# Altium schematic sheet 热网系统.SchDoc(热网系统)
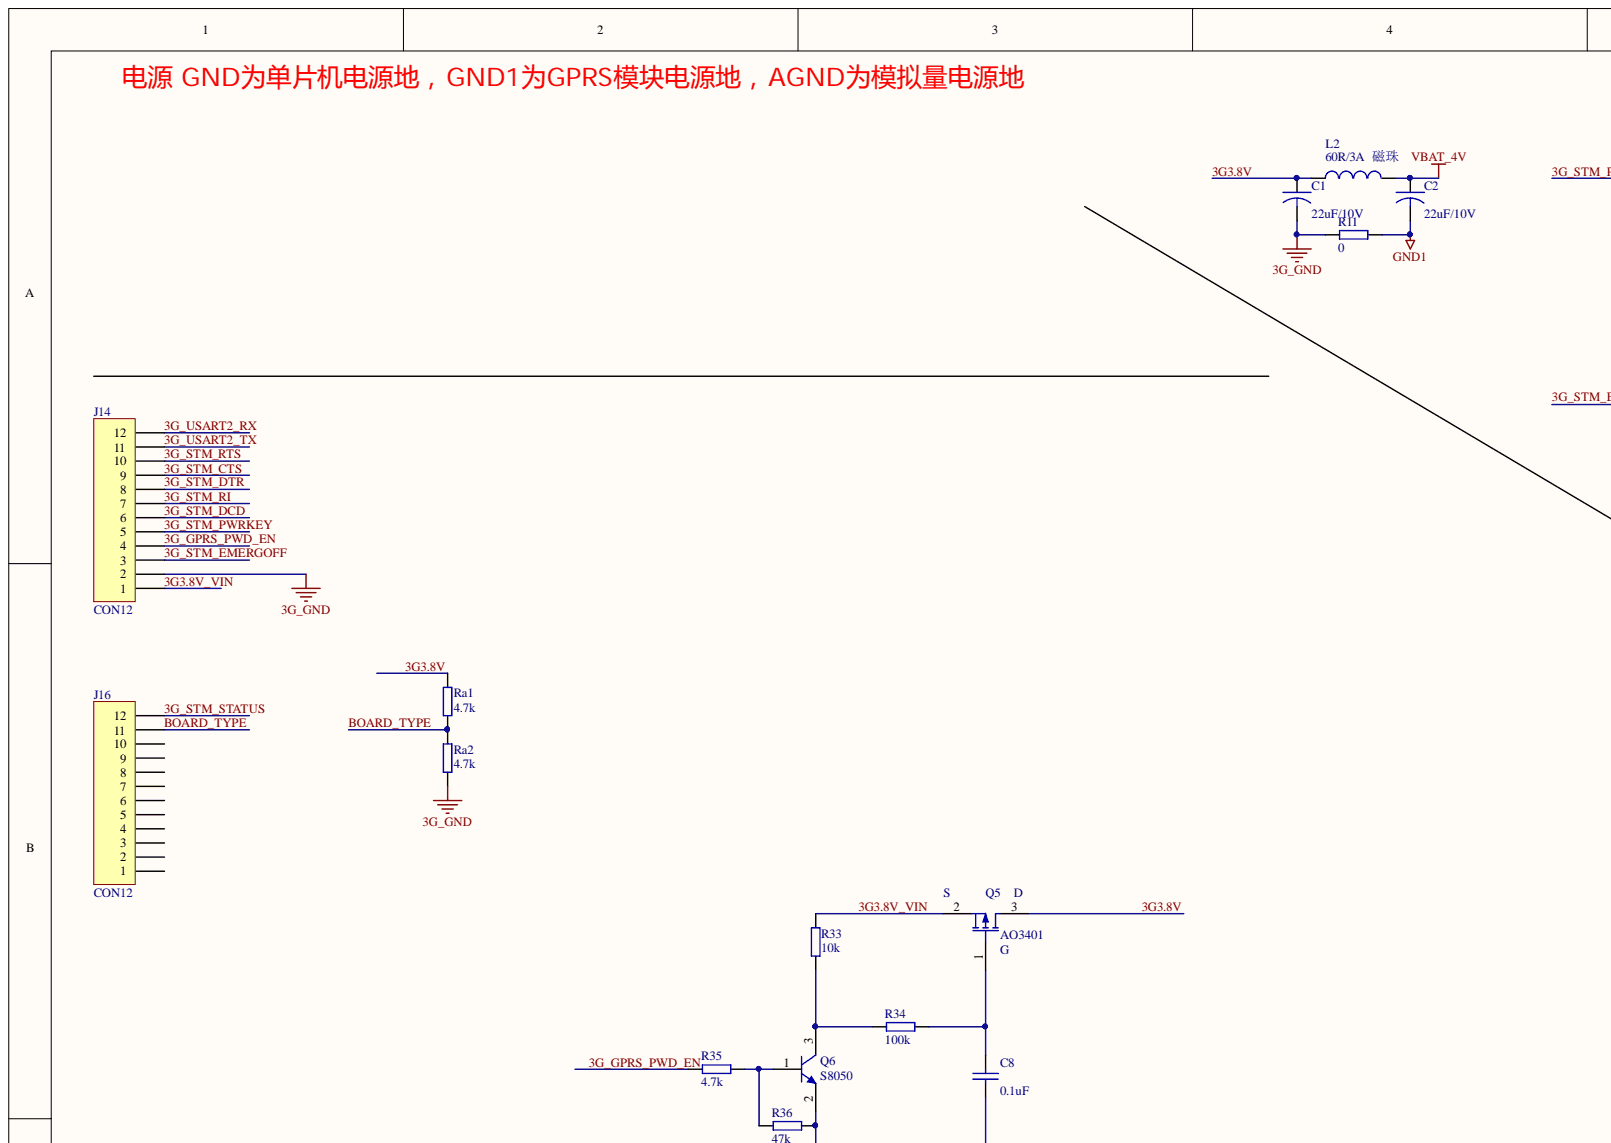
[diagram p0: 热网系统.SchDoc, top left]
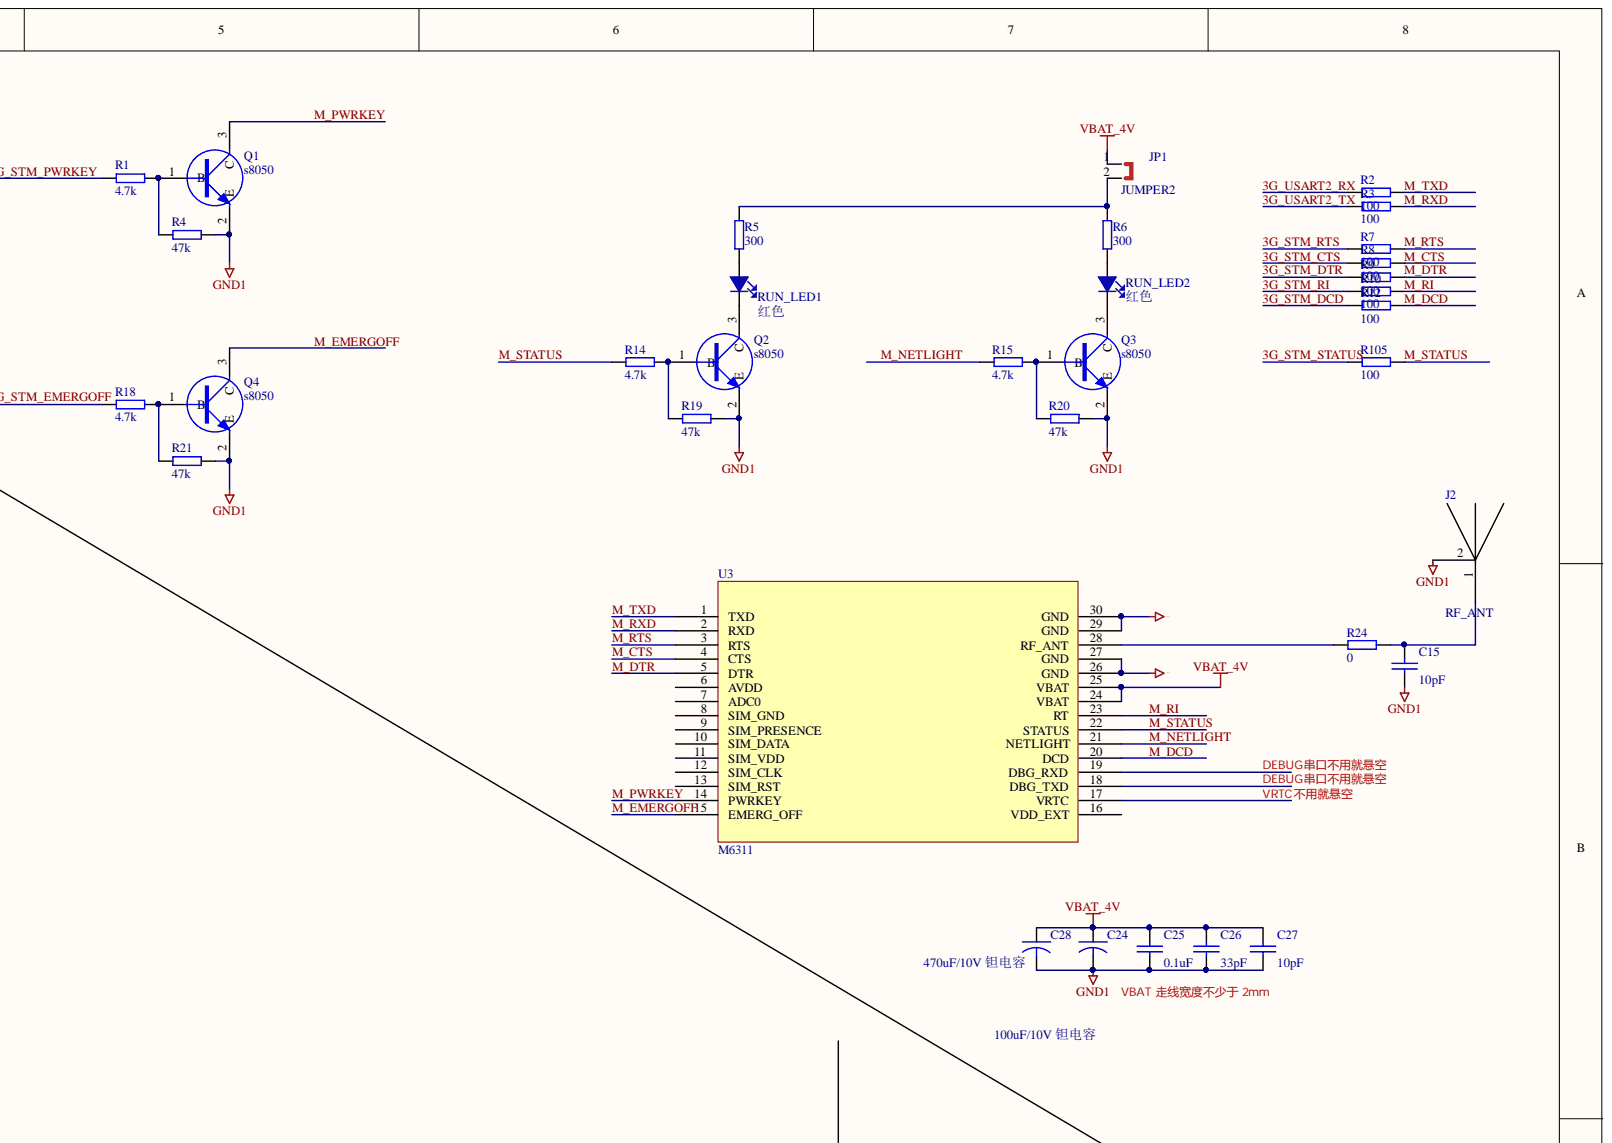
[diagram p1: 热网系统.SchDoc, top right]
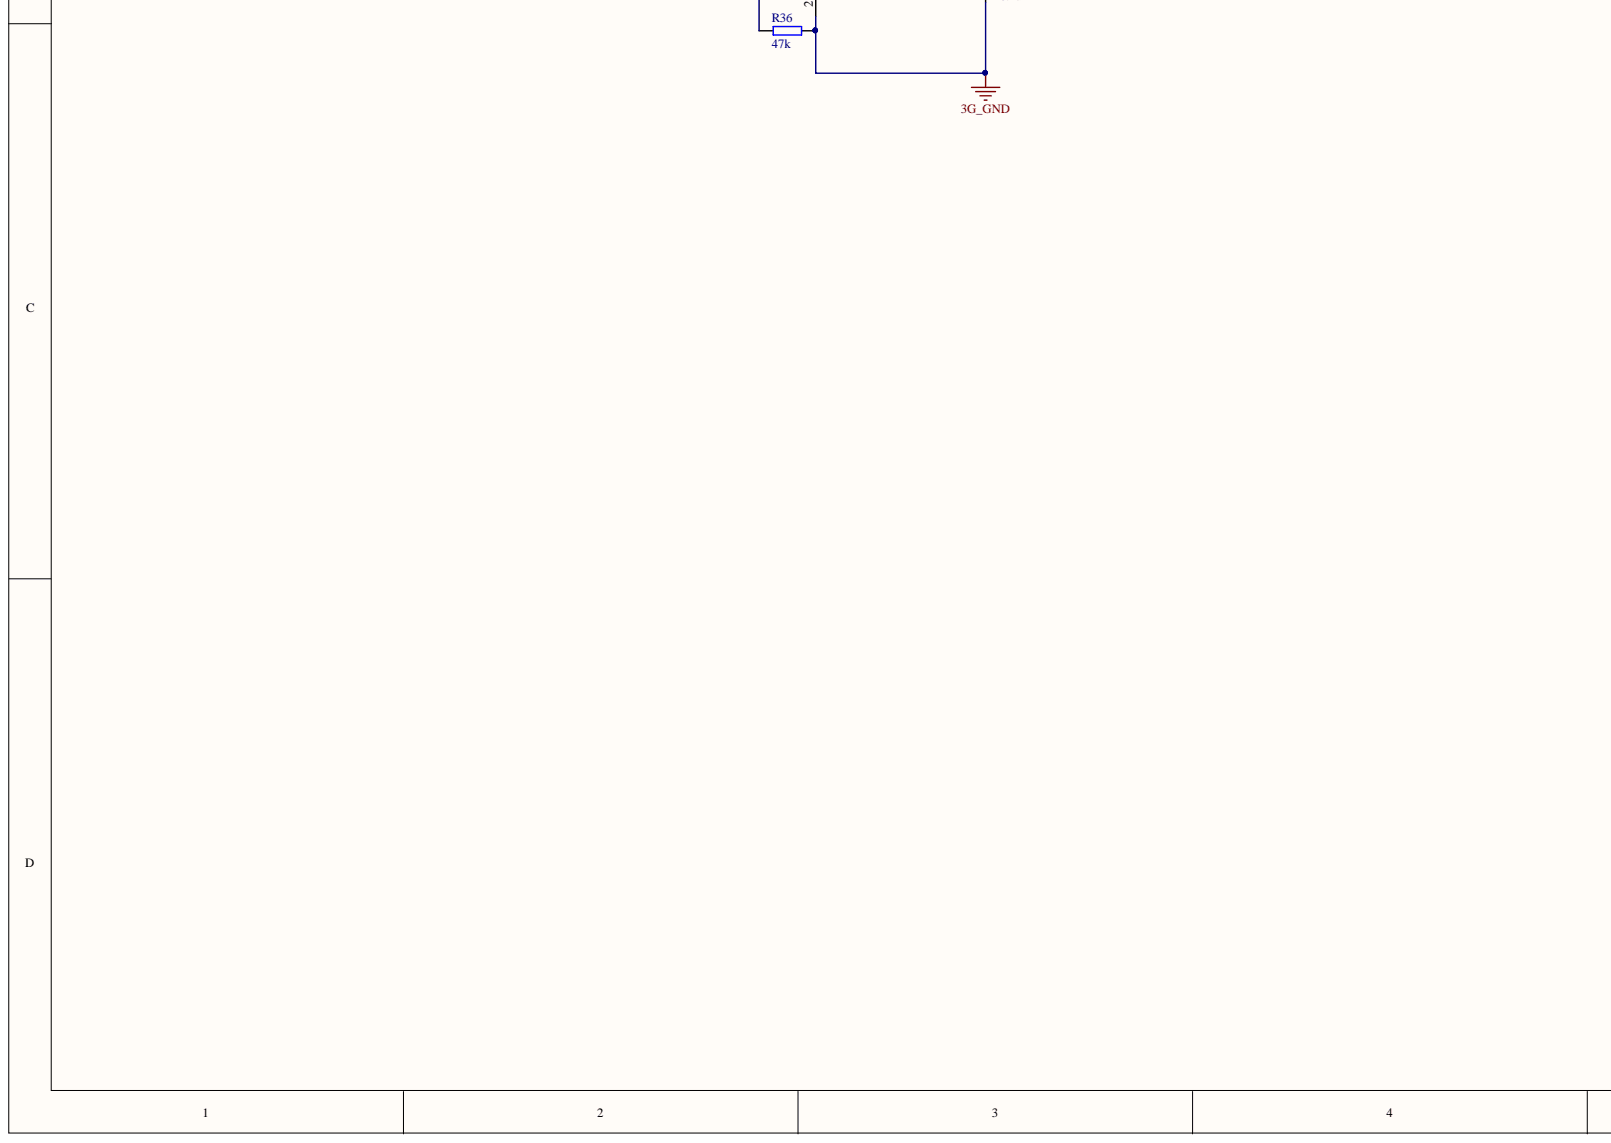
[diagram p2: 热网系统.SchDoc, bottom left]
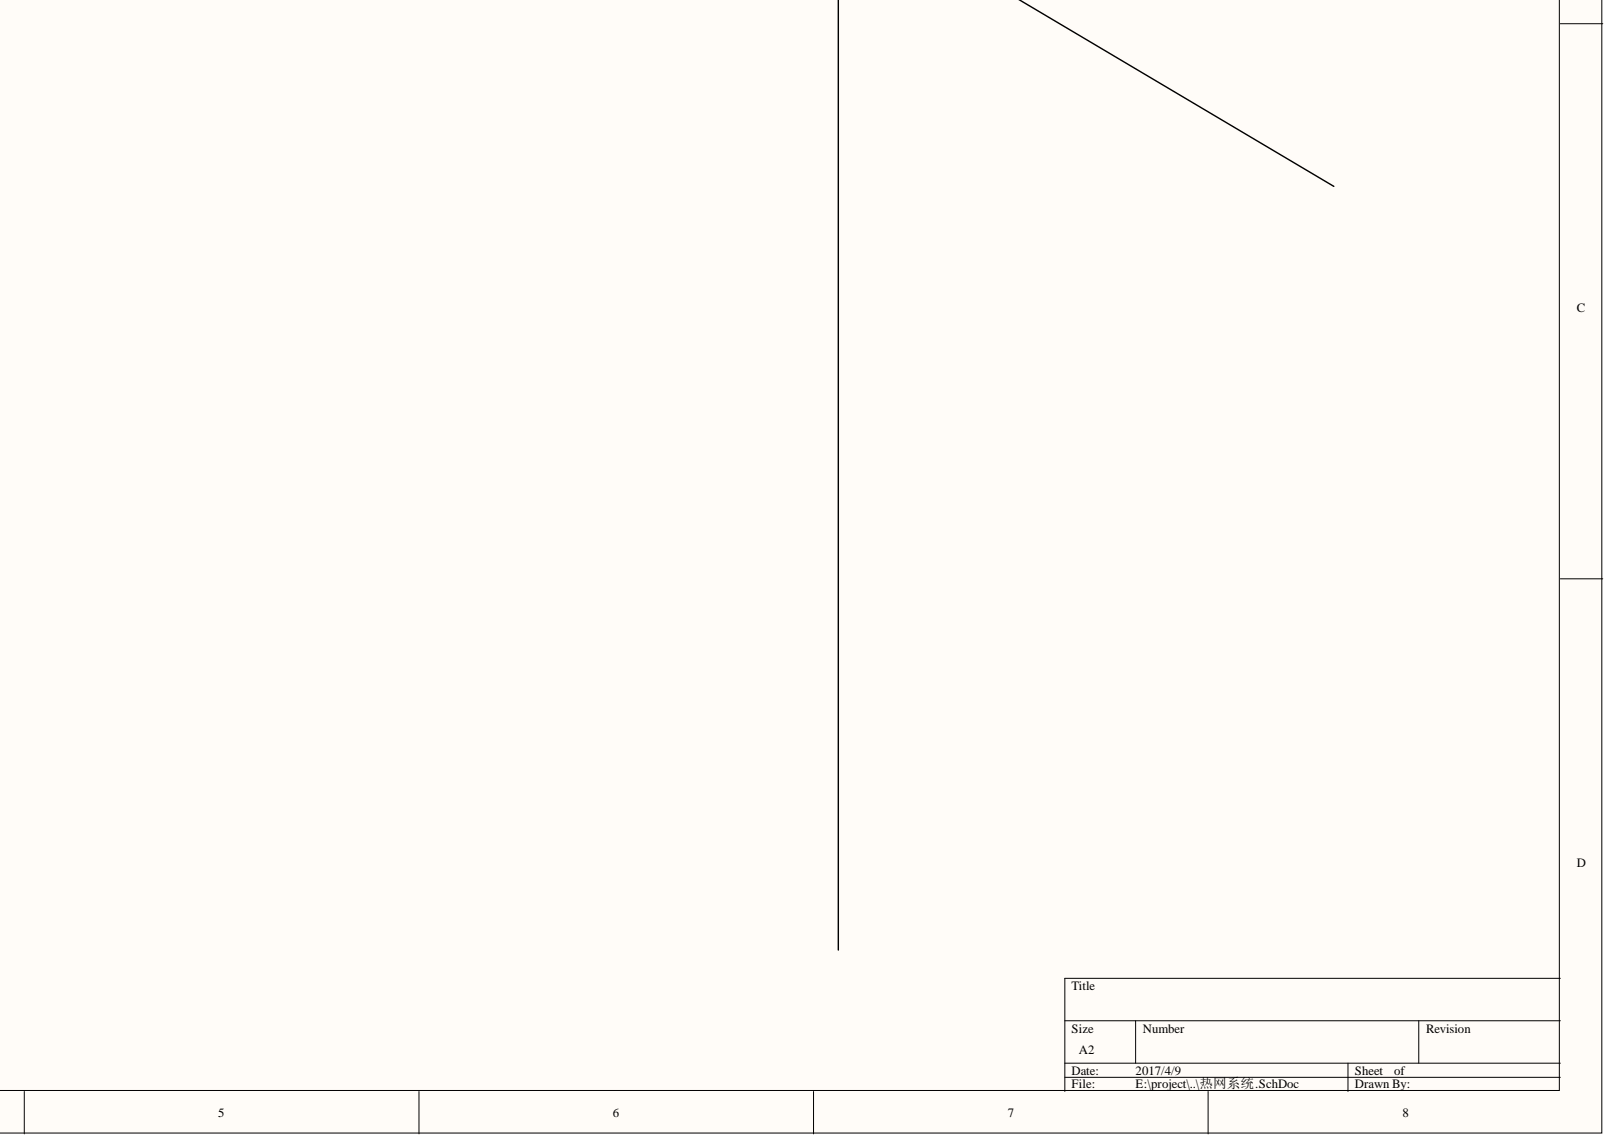
[diagram p3: 热网系统.SchDoc, bottom right]

## Parts
C1  22uF/10V  [CAP-1206]
C2  22uF/10V  [CAP-1206]
C8  0.1uF  [0603-1]
C15  10pF  [0603-1]
C24  100uF/10V 钽电容  [CAP-1210]
C25  0.1uF  [0603-1]
C26  33pF  [0603-1]
C27  10pF  [0603-1]
C28  470uF/10V 钽电容  [CAP-7343]
J2  RF_ANT  [U.FL-SMT]
J14  CON12  [HDR2X6 1.27]
J16  CON12  [HDR2X6 1.27]
JP1  JUMPER2  [HDR2X1-2.54]
L2  60R/3A  磁珠  [1206]
Q1  s8050  [SOT-23]
Q2  s8050  [SOT-23]
Q3  s8050  [SOT-23]
Q4  s8050  [SOT-23]
Q5  AO3401  [SOT23-3]
Q6  S8050  [SOT23-3]
R1  4.7k  [0603-1]
R2  100  [0603-1]
R3  100  [0603-1]
R4  47k  [0603-1]
R5  300  [0603-1]
R6  300  [0603-1]
R7  100  [0603-1]
R8  100  [0603-1]
R9  100  [0603-1]
R10  100  [0603-1]
R11  0  [0603-1]
R12  100  [0603-1]
R14  4.7k  [0603-1]
R15  4.7k  [0603-1]
R18  4.7k  [0603-1]
R19  47k  [0603-1]
R20  47k  [0603-1]
R21  47k  [0603-1]
R24  0  [0603-1]
R33  10k  [0603-1]
R34  100k  [0603-1]
R35  4.7k  [0603-1]
R36  47k  [0603-1]
R105  100  [0603-1]
Ra1  4.7k  [0603-1]
Ra2  4.7k  [0603-1]
RUN_LED1  红色  [LED-0805]
RUN_LED2  红色  [LED-0805]
U3  M6311  [M6311]

## Nets
3G_GND: C1.2 C8.2 J14.2 Q6.2 R11.2 R36.1 Ra2.2
GND1: C2.2 C15.2 C24.2 C25.2 C26.2 C27.2 C28.2 J2.2 Q1.2 Q2.2 Q3.2 Q4.2 R4.1 R11.1 R19.1 R20.1 R21.1 U3.26(GND) U3.27(GND) U3.29(GND) U3.30(GND)
VBAT_4V: C2.1 C24.1 C25.1 C26.1 C27.1 C28.1 JP1.1 L2.2 U3.24(VBAT) U3.25(VBAT)
3G3.8V: C1.1 L2.1 Q5.3 Ra1.1
3G3.8V_VIN: J14.1 Q5.2 R33.1
3G_GPRS_PWD_EN: J14.4 R35.1
3G_STM_CTS: J14.9 R8.2
3G_STM_DCD: J14.6 R12.2
3G_STM_DTR: J14.8 R9.2
3G_STM_EMERGOFF: J14.3 R18.2
3G_STM_PWRKEY: J14.5 R1.2
3G_STM_RI: J14.7 R10.2
3G_STM_RTS: J14.10 R7.2
3G_STM_STATUS: J16.12 R105.2
3G_USART2_RX: J14.12 R2.2
3G_USART2_TX: J14.11 R3.2
BOARD_TYPE: J16.11 Ra1.2 Ra2.1
M_CTS: R8.1 U3.4(CTS)
M_DCD: R12.1 U3.20(DCD)
M_DTR: R9.1 U3.5(DTR)
M_EMERGOFF: Q4.3 U3.15(EMERG_OFF)
M_NETLIGHT: R15.2 U3.21(NETLIGHT)
M_PWRKEY: Q1.3 U3.14(PWRKEY)
M_RI: R10.1 U3.23(RT)
M_RTS: R7.1 U3.3(RTS)
M_RXD: R3.1 U3.2(RXD)
M_STATUS: R14.2 R105.1 U3.22(STATUS)
M_TXD: R2.1 U3.1(TXD)
NetC8_1: C8.1 Q5.1 R34.2
NetC15_1: C15.1 J2.1 R24.1
NetJP1_2: JP1.2 R5.1 R6.1
NetQ1_1: Q1.1 R1.1 R4.2
NetQ2_1: Q2.1 R14.1 R19.2
NetQ2_3: Q2.3 RUN_LED1.2
NetQ3_1: Q3.1 R15.1 R20.2
NetQ3_3: Q3.3 RUN_LED2.2
NetQ4_1: Q4.1 R18.1 R21.2
NetQ6_1: Q6.1 R35.2 R36.2
NetQ6_3: Q6.3 R33.2 R34.1
NetR5_2: R5.2 RUN_LED1.1
NetR6_2: R6.2 RUN_LED2.1
NetR24_2: R24.2 U3.28(RF_ANT)

Not connected: J16 1 2 3 4 5 6 7 8 9 10; U3 6(AVDD) 7(ADC0) 8(SIM_GND) 9(SIM_PRESENCE) 10(SIM_DATA) 11(SIM_VDD) 12(SIM_CLK) 13(SIM_RST) 16(VDD_EXT) 17(VRTC) 18(DBG_TXD) 19(DBG_RXD)

## Library data of the parts
C1 C2 C24 C28 = CAPACITOR: Component Kind: Standard; Description: Capacitor; Library Name: JEC_PV_Devices.SchLib
C8 C15 C25-C27 = CAP: Capacitor; Component Kind: Standard; Library Name: JEC_PV_Devices.SchLib
J14 J16 = CON12: Connector; Component Kind: Standard; Library Name: 杂元件库.SCHLIB
JP1 = JUMPER2: Jumper; Component Kind: Standard; Library Name: 杂元件库.SCHLIB
L2 = INDUCTOR: 磁珠; Component Kind: Standard; Library Name: JEC_PV_Devices.SchLib
Q1-Q4 = NPN: NPN Transistor; Component Kind: Standard; Library Name: 杂元件库.SCHLIB
Q5 = MOSFET-P: PackageDocument: 1995/1996; Component Kind: Standard; Description: AO3401; LatestRevisionDate: 17-Jul-2002; LatestRevisionNote: Re-released for DXP Platform.; PackageReference: E3; Published: 8-Jun-2000; Library Name: fszhang.SchLib; Publisher: Altium Limited
Q6 = NPN1: 贴片三极管; Code_JEDEC: TO-92A; Component Kind: Standard; ComponentLink1Description: Manufacturer Link; ComponentLink1URL: http://www.fairchildsemi.com/; ComponentLink2Description: Datasheet; ComponentLink2URL: http://www.fairchildsemi.com/ds/2N%2F2N3904.pdf; DatasheetDocument: 1997; LatestRevisionDate: 15-Jan-2003; LatestRevisionNote: PCB Footprint 'BCY-W3/D4.7' replaced; PackageDocument: Sep-1998; PackageReference: TO-92A; Published: 8-Jun-2000; Library Name: fszhang.SchLib; Publisher: Altium Limited
R1-R12 R14 R15 R18-R21 R24 R33-R36 R105 Ra1 Ra2 = RES2: Component Kind: Standard; Library Name: ZJXU_EE_Devices.SchLib
RUN_LED1 RUN_LED2 = LED: Component Kind: Standard; Library Name: ZJXU_EE_Devices.SchLib
J2 = RF_ANT: Component Kind: Standard; Library Name: prevate.SchLib
U3 = M6311: Component Kind: Standard; Library Name: M6311.IntLib
